# Altium schematic sheet Host UC.SchDoc
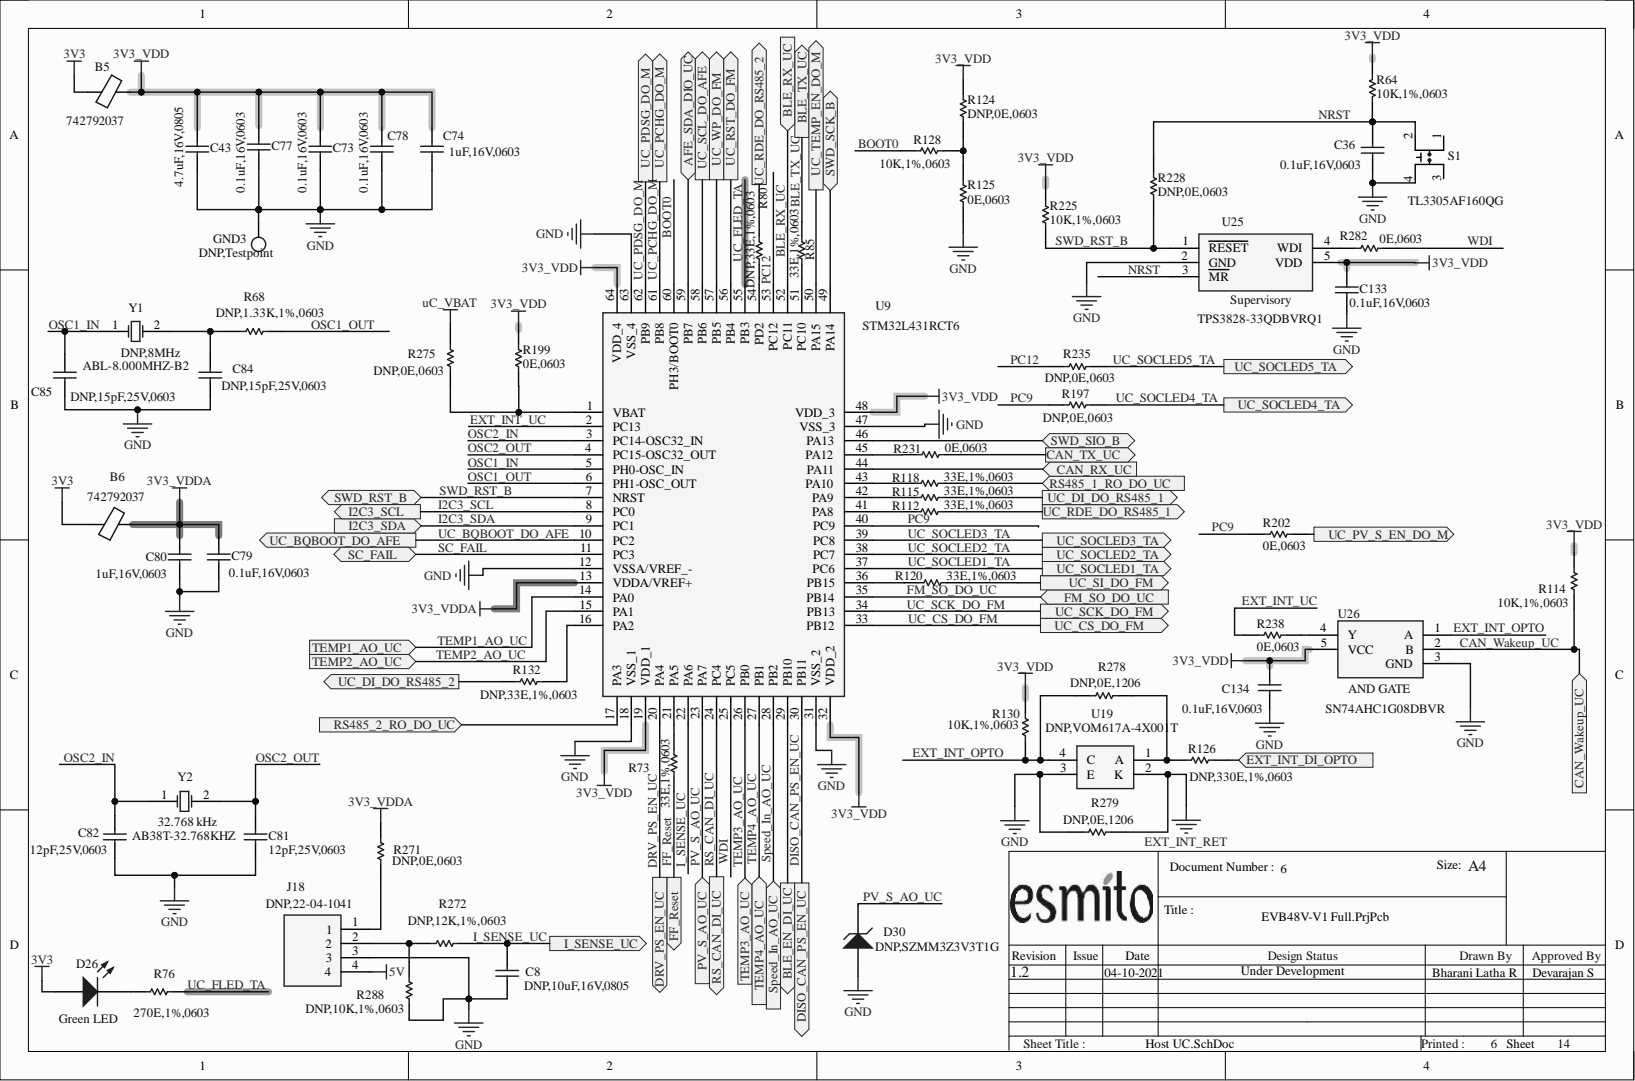
[diagram p0: Host UC.SchDoc]

## Parts
B5  value 742792037  Part Number: 742792037  [0805_R_M]  (hidden comment: B_LowQ)
B6  value 742792037  Part Number: 742792037  [0805_R_M]  (hidden comment: B_LowQ)
C8  value DNP,10uF,16V,0805  [0805_R_M]  (hidden comment: C_Semi)
C36  value 0.1uF,16V,0603  [0603_C_M]  (hidden comment: C_Semi)
C43  value 4.7uF,16V,0805  [0805_R_M]  (hidden comment: C_Semi)
C73  value 0.1uF,16V,0603  [0603_C_M]  (hidden comment: C_Semi)
C74  value 1uF,16V,0603  [0603_C_M]  (hidden comment: C_Semi)
C77  value 0.1uF,16V,0603  [0603_C_M]  (hidden comment: C_Semi)
C78  value 0.1uF,16V,0603  [0603_C_M]  (hidden comment: C_Semi)
C79  value 0.1uF,16V,0603  [0603_C_M]  (hidden comment: C_Semi)
C80  value 1uF,16V,0603  [0603_C_M]  (hidden comment: C_Semi)
C81  value 12pF,25V,0603  [0603_C_M]  (hidden comment: C_Semi)
C82  value 12pF,25V,0603  [0603_C_M]  (hidden comment: C_Semi)
C84  value DNP,15pF,25V,0603  [0603_C_M]  (hidden comment: C_Semi)
C85  value DNP,15pF,25V,0603  [0603_C_M]  (hidden comment: C_Semi)
C133  value 0.1uF,16V,0603  [0603_C_M]  (hidden comment: C_Semi)
C134  value 0.1uF,16V,0603  [0603_C_M]  (hidden comment: C_Semi)
D26  value Green LED  [0603_LED_M]  (hidden comment: LED0)
D30  value DNP,SZMM3Z3V3T1G  [SOD_323_M]  (hidden comment: D_Zener)
GND3  value DNP,Testpoint  [Keystone5002]  (hidden comment: TEST POINT)
J18  22-04-1041  value DNP,22-04-1041  [SHDR4W64P0X250_1X4_950X580X1210P]
R64  value 10K,1%,0603  [0603_R_M]  (hidden comment: R_Semi)
R68  value DNP,1.33K,1%,0603  [0603_R_M]  (hidden comment: R_Semi)
R73  value 33E,1%,0603  [0603_R_M]  (hidden comment: R_Semi)
R76  value 270E,1%,0603  [0603_R_M]  (hidden comment: R_Semi)
R80  value DNP,33E,1%,0603  [0603_R_M]  (hidden comment: R_Semi)
R85  value 33E,1%,0603  [0603_R_M]  (hidden comment: R_Semi)
R112  value 33E,1%,0603  [0603_R_M]  (hidden comment: R_Semi)
R114  value 10K,1%,0603  [0603_R_M]  (hidden comment: R_Semi)
R115  value 33E,1%,0603  [0603_R_M]  (hidden comment: R_Semi)
R118  value 33E,1%,0603  [0603_R_M]  (hidden comment: R_Semi)
R120  value 33E,1%,0603  [0603_R_M]  (hidden comment: R_Semi)
R124  value DNP,0E,0603  [0603_R_M]  (hidden comment: R_Semi)
R125  value 0E,0603  [0603_R_M]  (hidden comment: R_Semi)
R126  value DNP,330E,1%,0603  [0603_R_M]  (hidden comment: R_Semi)
R128  value 10K,1%,0603  [0603_R_M]  (hidden comment: R_Semi)
R130  value 10K,1%,0603  [0603_R_M]  (hidden comment: R_Semi)
R132  value DNP,33E,1%,0603  [0603_R_M]  (hidden comment: R_Semi)
R197  value DNP,0E,0603  [0603_R_M]  (hidden comment: R_Semi)
R199  value 0E,0603  [0603_R_M]  (hidden comment: R_Semi)
R202  value 0E,0603  [0603_R_M]  (hidden comment: R_Semi)
R225  value 10K,1%,0603  [0603_R_M]  (hidden comment: R_Semi)
R228  value DNP,0E,0603  [0603_R_M]  (hidden comment: R_Semi)
R231  value 0E,0603  [0603_R_M]  (hidden comment: R_Semi)
R235  value DNP,0E,0603  [0603_R_M]  (hidden comment: R_Semi)
R238  value 0E,0603  [0603_R_M]  (hidden comment: R_Semi)
R271  value DNP,0E,0603  [0603_R_M]  (hidden comment: R_Semi)
R272  value DNP,12K,1%,0603  [0603_R_M]  (hidden comment: R_Semi)
R275  value DNP,0E,0603  [0603_R_M]  (hidden comment: R_Semi)
R278  value DNP,0E,1206  [1206_C_M]  (hidden comment: R_Semi)
R279  value DNP,0E,1206  [1206_C_M]  (hidden comment: R_Semi)
R282  value 0E,0603  [0603_R_M]  (hidden comment: R_Semi)
R288  value DNP,10K,1%,0603  [0603_R_M]  (hidden comment: R_Semi)
S1  value TL3305AF160QG  Part Number: TL3305AF160QG  [TactileSwitch_M]  (hidden comment: SW_SPST_4)
U9  STM32L431RCT6  [QFP50P1200X1200X160-64N]
U19  value DNP,VOM617A-4X001T  [VOM617A8X001T]  (hidden comment: VOM617A-4T)
U25  Supervisory  value TPS3828-33QDBVRQ1  [SOT95P280X145-5N]
U26  AND GATE  value SN74AHC1G08DBVR  [SOT95P280X145-5N]
Y1  ABL-8.000MHZ-B2  value DNP,8MHz  [HC/49US_(AT49)PTH]
Y2  value 32.768 kHz  [XTAL_Round]  (hidden comment: XTAL)

## Ports
AFE_SDA_DIO_UC, BLE_EN_DI_UC, BLE_RX_UC, BLE_TX_UC, CAN_RX_UC, CAN_TX_UC, CAN_Wakeup_UC, DISO_CAN_PS_EN_UC, DRV_PS_EN_UC, EXT_INT_DI_OPTO, FF_Reset, FM_SO_DO_UC, I2C3_SCL, I2C3_SDA, I_SENSE_UC, PV_S_AO_UC, RS485_1_RO_DO_UC, RS485_2_RO_DO_UC, RS_CAN_DI_UC, SC_FAIL, Speed_In_AO_UC, SWD_RST_B, SWD_SCK_B, SWD_SIO_B, TEMP1_AO_UC, TEMP2_AO_UC, TEMP3_AO_UC, TEMP4_AO_UC, UC_BQBOOT_DO_AFE, UC_CS_DO_FM, UC_DI_DO_RS485_1, UC_DI_DO_RS485_2, UC_PCHG_DO_M, UC_PDSG_DO_M, UC_PV_S_EN_DO_M, UC_RDE_DO_RS485_1, UC_RDE_DO_RS485_2, UC_RST_DO_FM, UC_SCK_DO_FM, UC_SCL_DO_AFE, UC_SI_DO_FM, UC_SOCLED1_TA, UC_SOCLED2_TA, UC_SOCLED3_TA, UC_SOCLED4_TA, UC_SOCLED5_TA, UC_TEMP_EN_DO_M, UC_WP_DO_FM

## Nets
3V3: B5.1 B6.1 D26.1
3V3_VDD: B5.2 C43.1 C73.1 C74.1 C77.1 C78.1 C133.1 C134.1 R64.1 R114.1 R124.1 R130.1 R199.2 R225.1 U9.19(VDD_1) U9.32(VDD_2) U9.48(VDD_3) U9.64(VDD_4) U25.5(VDD) U26.5(VCC)
3V3_VDDA: B6.2 C79.1 C80.1 R271.2 U9.13(VDDA/VREF+)
5V: J18.4
EXT_INT_RET: R279.1 U19.2(K)
GND: C8.1 C36.2 C43.2 C73.2 C74.2 C77.2 C78.2 C79.2 C80.2 C81.1 C82.1 C84.1 C85.1 C133.2 C134.2 D30.1 GND3.1 J18.3 R125.2 R279.2 R288.1 S1.4 U9.12(VSSA/VREF_-) U9.18(VSS_1) U9.31(VSS_2) U9.47(VSS_3) U9.63(VSS_4) U19.3(E) U25.2(GND) U26.3(GND)
uC_VBAT: R275.2
AFE_SDA_DIO_UC: U9.59(PB7) ; port AFE_SDA_DIO_UC
BLE_EN_DI_UC: U9.29(PB10) ; port BLE_EN_DI_UC
BLE_RX_UC: U9.52(PC11) ; port BLE_RX_UC
BLE_TX_UC: R85.1 ; port BLE_TX_UC
BOOT0: R128.2 U9.60(PH3/BOOT0)
CAN_RX_UC: U9.44(PA11) ; port CAN_RX_UC
CAN_TX_UC: R231.1 ; port CAN_TX_UC
CAN_Wakeup_UC: R114.2 U26.2(B) ; port CAN_Wakeup_UC
DISO_CAN_PS_EN_UC: U9.30(PB11) ; port DISO_CAN_PS_EN_UC
DRV_PS_EN_UC: U9.20(PA4) ; port DRV_PS_EN_UC
EXT_INT_DI_OPTO: R126.2 ; port EXT_INT_DI_OPTO
EXT_INT_OPTO: R130.2 R278.2 U19.4(C) U26.1(A)
EXT_INT_UC: R238.1 U9.2(PC13)
FF_Reset: R73.1 ; port FF_Reset
FM_SO_DO_UC: U9.35(PB14) ; port FM_SO_DO_UC
I2C3_SCL: U9.8(PC0) ; port I2C3_SCL
I2C3_SDA: U9.9(PC1) ; port I2C3_SDA
I_SENSE_UC: C8.2 R272.1 U9.22(PA6) ; port I_SENSE_UC
NRST: C36.1 R64.2 R228.2 S1.2 U25.3(MR)
OSC1_IN: C85.2 U9.5(PH0-OSC_IN) Y1.1
OSC1_OUT: R68.2 U9.6(PH1-OSC_OUT)
OSC2_IN: C82.2 U9.3(PC14-OSC32_IN) Y2.1
OSC2_OUT: C81.2 U9.4(PC15-OSC32_OUT) Y2.2
PC9: R197.1 R202.1 U9.40(PC9)
PC12: R235.1 U9.53(PC12)
PV_S_AO_UC: D30.2 U9.23(PA7) ; port PV_S_AO_UC
RS485_1_RO_DO_UC: R118.2 ; port RS485_1_RO_DO_UC
RS485_2_RO_DO_UC: U9.17(PA3) ; port RS485_2_RO_DO_UC
RS_CAN_DI_UC: U9.24(PC4) ; port RS_CAN_DI_UC
SC_FAIL: U9.11(PC3) ; port SC_FAIL
Speed_In_AO_UC: U9.28(PB2) ; port Speed_In_AO_UC
SWD_RST_B: R225.2 R228.1 U9.7(NRST) U25.1(RESET) ; port SWD_RST_B
SWD_SCK_B: U9.49(PA14) ; port SWD_SCK_B
SWD_SIO_B: U9.46(PA13) ; port SWD_SIO_B
TEMP1_AO_UC: U9.14(PA0) ; port TEMP1_AO_UC
TEMP2_AO_UC: U9.15(PA1) ; port TEMP2_AO_UC
TEMP3_AO_UC: U9.26(PB0) ; port TEMP3_AO_UC
TEMP4_AO_UC: U9.27(PB1) ; port TEMP4_AO_UC
UC_BQBOOT_DO_AFE: U9.10(PC2) ; port UC_BQBOOT_DO_AFE
UC_CS_DO_FM: U9.33(PB12) ; port UC_CS_DO_FM
UC_DI_DO_RS485_1: R115.1 ; port UC_DI_DO_RS485_1
UC_DI_DO_RS485_2: R132.1 ; port UC_DI_DO_RS485_2
UC_FLED_TA: R76.1 U9.55(PB3)
UC_PCHG_DO_M: U9.61(PB8) ; port UC_PCHG_DO_M
UC_PDSG_DO_M: U9.62(PB9) ; port UC_PDSG_DO_M
UC_PV_S_EN_DO_M: R202.2 ; port UC_PV_S_EN_DO_M
UC_RDE_DO_RS485_1: R112.2 ; port UC_RDE_DO_RS485_1
UC_RDE_DO_RS485_2: R80.1 ; port UC_RDE_DO_RS485_2
UC_RST_DO_FM: U9.56(PB4) ; port UC_RST_DO_FM
UC_SCK_DO_FM: U9.34(PB13) ; port UC_SCK_DO_FM
UC_SCL_DO_AFE: U9.58(PB6) ; port UC_SCL_DO_AFE
UC_SI_DO_FM: R120.2 ; port UC_SI_DO_FM
UC_SOCLED1_TA: U9.37(PC6) ; port UC_SOCLED1_TA
UC_SOCLED2_TA: U9.38(PC7) ; port UC_SOCLED2_TA
UC_SOCLED3_TA: U9.39(PC8) ; port UC_SOCLED3_TA
UC_SOCLED4_TA: R197.2 ; port UC_SOCLED4_TA
UC_SOCLED5_TA: R235.2 ; port UC_SOCLED5_TA
UC_TEMP_EN_DO_M: U9.50(PA15) ; port UC_TEMP_EN_DO_M
UC_WP_DO_FM: U9.57(PB5) ; port UC_WP_DO_FM
WDI: R282.1 U9.25(PC5)
NetC84_2: C84.2 R68.1 Y1.2
NetD26_2: D26.2 R76.2
NetJ18_1: J18.1 R271.1
NetJ18_2: J18.2 R272.2 R288.2
NetR73_2: R73.2 U9.21(PA5)
NetR80_2: R80.2 U9.54(PD2)
NetR85_2: R85.2 U9.51(PC10)
NetR112_1: R112.1 U9.41(PA8)
NetR115_2: R115.2 U9.42(PA9)
NetR118_1: R118.1 U9.43(PA10)
NetR120_1: R120.1 U9.36(PB15)
NetR124_2: R124.2 R125.1 R128.1
NetR126_1: R126.1 R278.1 U19.1(A)
NetR132_2: R132.2 U9.16(PA2)
NetR199_1: R199.1 R275.1 U9.1(VBAT)
NetR231_2: R231.2 U9.45(PA12)
NetR238_2: R238.2 U26.4(Y)
NetR282_2: R282.2 U25.4(WDI)

Not connected: S1 1 3

## Library data of the parts
B5 B6 = B_LowQ: Ferrite Bead WE-CBF 0805 SMD Bead 100MHz 330Ohm 2A; Alternate Manufacturer: Murata; Alternate Part Number: BLM21PG331SN1D; Manufacturer: Wurth Elektronik; Component Kind: Standard; Library Name: Grinntech_Global_Library.IntLib
C8 = C_Semi: MLCC - 0805 16V 10uF X7R 10 %; Alternate Manufacturer: Taiyo Yuden; Alternate Part Number: EMK212BB7106KG-T; Manufacturer: Yageo; Part Number: CC0805KKX7R7BB106; Component Kind: Standard; Signal Integrity: Cap Semi; Simulation: CAP; Library Name: Grinntech_Global_Library.IntLib
C36 C73 C77-C79 C133 C134 = C_Semi: MLCC - 0603 100nF 16V X7R 10%; Alternate Manufacturer: AVX; Alternate Part Number: 0603YC104K4T2A; Manufacturer: Yageo; Part Number: CC603KRX7R7BB104; Component Kind: Standard; Signal Integrity: Cap Semi; Simulation: CAP; Library Name: Grinntech_Global_Library.IntLib
C43 = C_Semi: MLCC - 0805 4.7uF 16V X7R 10%; Alternate Manufacturer: Murata; Alternate Part Number: GCM21BR71C475KA73K; Manufacturer: Yageo; Part Number: CC0805KKX7R7BB475; Component Kind: Standard; Signal Integrity: Cap Semi; Simulation: CAP; Library Name: Grinntech_Global_Library.IntLib
C74 C80 = C_Semi: MLCC - 0603 1uF 16V X5R 10%; Alternate Manufacturer: Murata; Alternate Part Number: GCM188R71C105KA64D; Manufacturer: Yageo; Part Number: CC603KRX5R7BB105; Component Kind: Standard; Signal Integrity: Cap Semi; Simulation: CAP; Library Name: Grinntech_Global_Library.IntLib
C81 C82 = C_Semi: CAP, CERM, 12pF, 25V, 1%, C0G, 0603; Alternate Manufacturer: Yageo; Alternate Part Number: CC0603JRNPO9BN120; Manufacturer: Vishay; Part Number: VJ0603A120FXXPW1BC; Component Kind: Standard; Signal Integrity: Cap Semi; Simulation: CAP; Library Name: Grinntech_Global_Library.IntLib
C84 C85 = C_Semi: CAP, CERM, 15pF, 25V, 1%, C0G, 0603; Alternate Manufacturer: Yageo; Alternate Part Number: CC0603JRNPO9BN150; Manufacturer: Vishay; Part Number: VJ0603A150FXXPW1BC; Component Kind: Standard; Signal Integrity: Cap Semi; Simulation: CAP; Library Name: Grinntech_Global_Library.IntLib
D26 = LED0: Diode, Led, 2V, 20mA, 0603; Alternate Manufacturer: Lite-ON; Alternate Part Number: LTST-C190KGKT; Manufacturer: ROHM; Part Number: SML-D12M8WT86; Component Kind: Standard; Simulation: LED0; Library Name: Grinntech_Global_Library.IntLib
D30 = D_Zener: Diode, Zener, 3.3V, SOD323-2; Manufacturer: ON Semiconductor; Part Number: SZMM3Z3V3T1G; Component Kind: Standard; Signal Integrity: D Zener; Simulation: ZENER; Library Name: Grinntech_Global_Library.IntLib
GND3 = T POINT R: PCB TEST POINT BLACK 1mm; Manufacturer: Keystone Electronics; Part Number: 5001; Component Kind: Standard
J18 = 22-04-1041: Headers & Wire Housing 2.5MM HDR VERT 4P; Manufacturer: Molex; Component Kind: Standard; Part Number: 22-04-1041; Library Name: AFE_UC_Active_Conn.SchLib
R64 R114 R128 R130 R225 R288 = R_Semi: R, THK FLM, 10K, 1%, 100mW, 0603; Alternate Manufacturer: Vishay; Alternate Part Number: CRCW060310K0FKEA; Manufacturer: Yageo; Part Number: RC0603FR-0710KL; Component Kind: Standard; Signal Integrity: Res Semi; Simulation: RES; Library Name: Grinntech_Global_Library.IntLib
R68 = R_Semi: R, THK FLM, 1.33K, 1%, 100mW, 0603; Manufacturer: Yageo; Part Number: RC0603FR-071K33L; Component Kind: Standard; Signal Integrity: Res Semi; Simulation: RES; Library Name: Grinntech_Global_Library.IntLib
R73 R80 R85 R112 R115 R118 R120 = R_Semi: R, THK FLM, 33E, 1%, 100mW, 0603; Alternate Manufacturer: Yageo; Alternate Part Number: AC0603FR-0733RL; Part Number: RC0603FR-0733RL; Component Kind: Standard; Manufacturer: Yageo; Signal Integrity: Res Semi; Simulation: RES; Library Name: Grinntech_Global_Library.IntLib
R76 = R_Semi: R, THK FLM, 270E, 1%, 100mW, 0603; Alternate Manufacturer: KOA; Alternate Part Number: RK73H1JTTD2700F; Manufacturer: Yageo; Part Number: RC0603FR-10270RL; Value: 270E,1%,0603; Component Kind: Standard; Signal Integrity: Res Semi; Simulation: RES; Library Name: Grinntech_Global_Library.IntLib
R124 R125 R197 R199 R202 R228 R231 R235 R238 R271 R275 R282 = R_Semi: R, THK FLM, 0E,100mW,  0603; Alternate Manufacturer: Vishay; Alternate Part Number: CRCW06030000Z0EAC; Manufacturer: Yageo; Part Number: RC0603FR-070RL; Component Kind: Standard; Signal Integrity: Res Semi; Simulation: RES; Library Name: Grinntech_Global_Library.IntLib
R126 = R_Semi: R, THK FLM, 330E, 1%, 100mW, 0603; Manufacturer: Yageo; Part Number: RC0603FR-07330RL; Component Kind: Standard; Signal Integrity: Res Semi; Simulation: RES; Library Name: Grinntech_Global_Library.IntLib
R132 = R_Semi: R, THK FLM, 33E, 1%, 100mW, 0603; Alternate Manufacturer: Yageo; Alternate Part Number: AC0603FR-0733RL; Part Number: RC0603FR-0733RL; Value: DNP,33E,1%,0603; Component Kind: Standard; Manufacturer: Yageo; Signal Integrity: Res Semi; Simulation: RES; Library Name: Grinntech_Global_Library.IntLib
R272 = R_Semi: R, THK FLM, 12K, 1%, 100mW, 0603; Manufacturer: Yageo; Part Number: RC0603FR-0712KL; Component Kind: Standard; Signal Integrity: Res Semi; Simulation: RES; Library Name: Grinntech_Global_Library.IntLib
R278 R279 = R_Semi: R, THK FLM, 0E, 5%, 500mW, 1206; Manufacturer: Yageo; Part Number: AC1210JR-070RL; Component Kind: Standard; Signal Integrity: Res Semi; Simulation: RES; Library Name: Grinntech_Global_Library.IntLib
S1 = SW_SPST_4: Tactile Switch; Manufacturer: E- Switch; Component Kind: Standard; Library Name: Grinntech_Global_Lib.IntLib
U9 = STM32L431RBT6: ARM Microcontrollers - MCU 16/32-BITS LQFP-64; Manufacturer: STMicroelectronics; Component Kind: Standard; Part Number: STM32L431RCT6; Value: STM32L431RCT6; Library Name: AFE_UC_Active_Conn.SchLib
U19 = VOM617A-4T: Transistor Output Optocouplers 80V Vceo; Alternate Manufacturer: Vishay; Alternate Part Number: VOMA617A-4X001T; Part Number: VOM617A-4X001T; Component Kind: Standard; Manufacturer: Vishay
U25 = TPS3828-33QDBVRQ1: Supervisory Circuit Processor 1 CHANNEL SOT23-5; Manufacturer: Texas Instruments; Component Kind: Standard; Part Number: TPS3828-33QDBVRQ1; Value: TPS3828-33QDBVRQ1
U26 = SN74AHC1G08DBVR: LOGIC GATE AND 1CH 2-IN SOT23-5; Manufacturer: Texas Instruments; Component Kind: Standard; Part Number: SN74AHC1G08DBVR
Y1 = XTAL: Crystal +/-20ppm 8MHZ FUNDAMENTAL; Manufacturer: Abracon; Component Kind: Standard; Part Number: ABL-8.000MHZ-B2; Simulation: XTAL; Library Name: Grinntech_Global_Lib.IntLib
Y2 = XTAL: Crystal, 32.768 kHz; Alternate Manufacturer: IQD Frequency Products; Alternate Part Number: LFXTAL002996Bulk; Manufacturer: Abracon; Part Number: AB38T-32.768KHZ; Component Kind: Standard; Simulation: XTAL; Library Name: Grinntech_Global_Lib.IntLib
